# Altium schematic sheet 03-Power.SchDoc
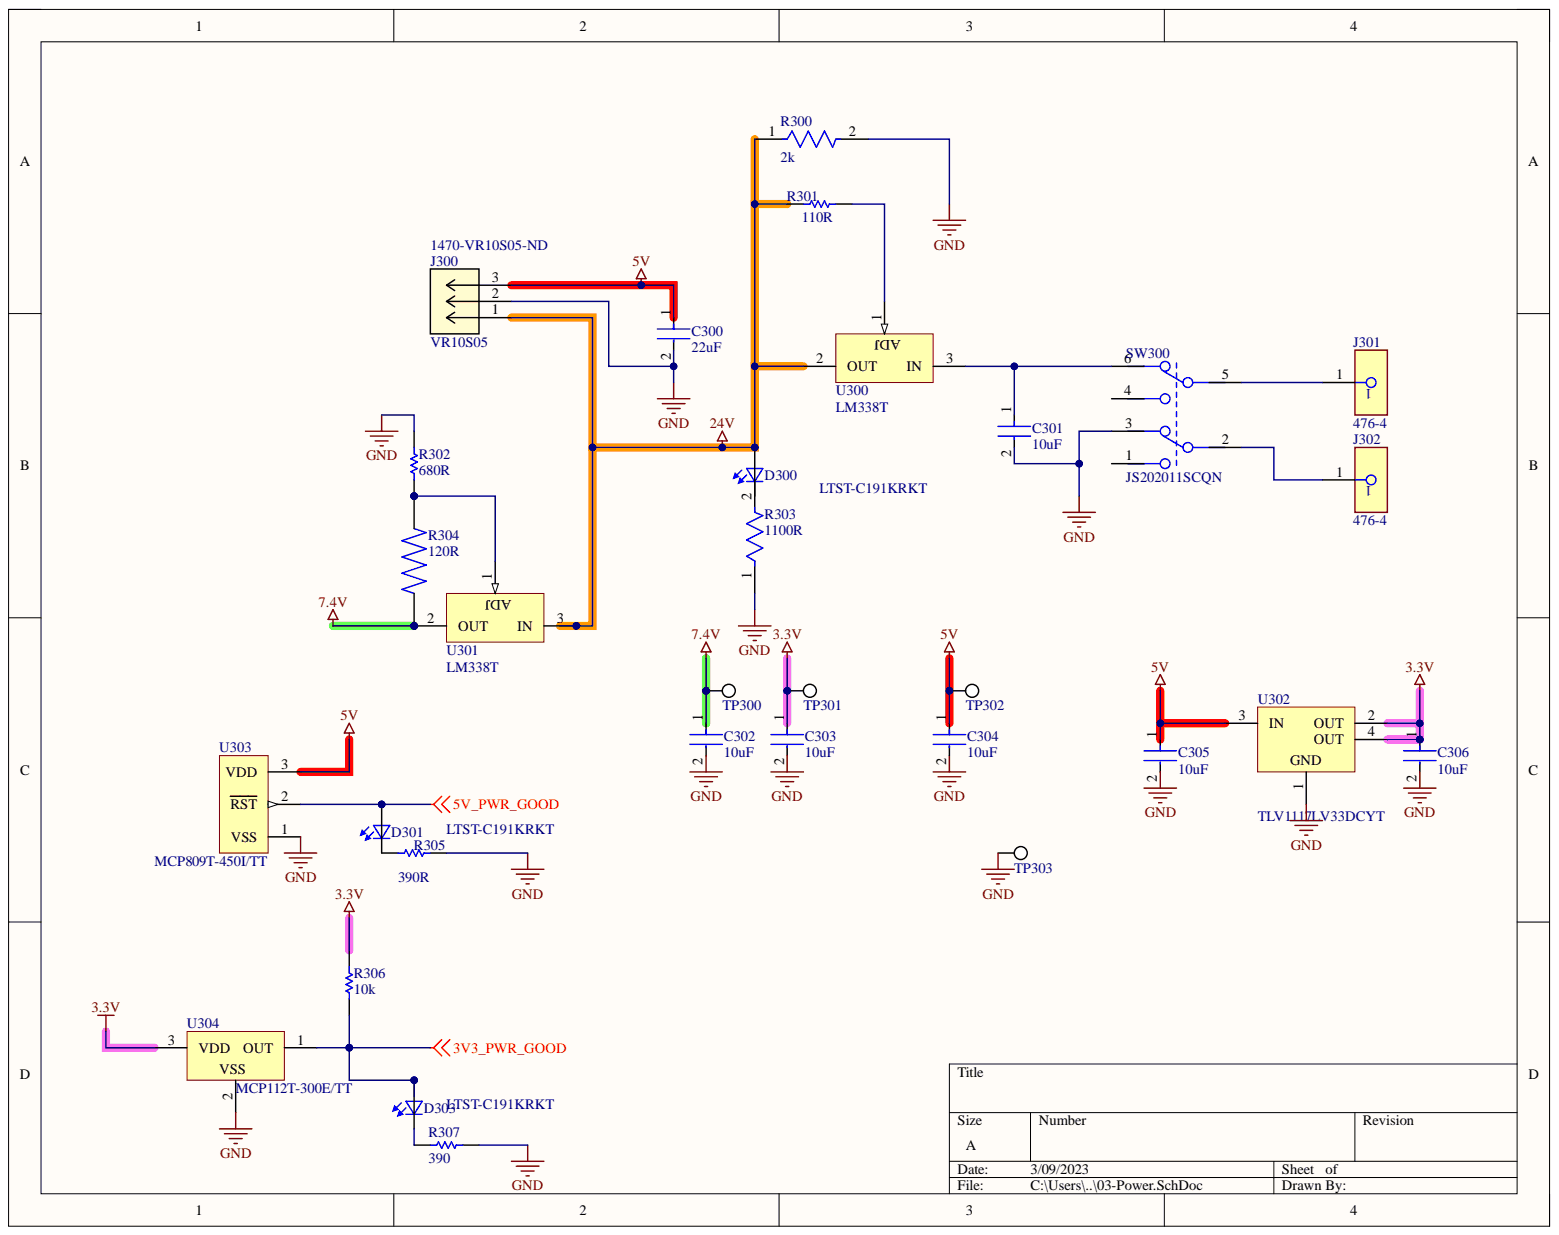
[diagram p0: 03-Power.SchDoc]

## Parts
C300  22uF  [FP-GRM21B-0_15-MFG]
C301  10uF  [FP-C0805C-DG-MFG]
C302  10uF  [FP-C0805C-DG-MFG]
C303  10uF  [FP-C0805C-DG-MFG]
C304  10uF  [FP-C0805C-DG-MFG]
C305  10uF  [FP-C0805C-DG-MFG]
C306  10uF  [FP-C0805C-DG-MFG]
D300  LTST-C191KRKT  [0603]
D301  LTST-C191KRKT  [0603]
D303  LTST-C191KRKT  [0603]
J300  VR10S05  Supplier Part Number 1: 1470-VR10S05-ND  [CONN3_10S05_XPP]
J301  476-4  [FP-476-4-MFG]
J302  476-4  [FP-476-4-MFG]
R300  2k  [FP-CRCW0805-e3-IPC_B]
R301  110R  [RESC2013X60X40NL20T25]
R302  680R  [RESC2013X50X30NL20T20]
R303  1100R  [FP-ESR10-MFG]
R304  120R  [RESC2012X65N]
R305  390R  [RESC3216X70X50LL05T20]
R306  10k  [RESC2013X60X35ML10T20]
R307  390  [RESC3216X70X50LL05T20]
SW300  JS202011SCQN  [FP-JS202011SCQN-MFG]
TP300  5019  [KSTN-5019_V]  (value not drawn)
TP301  5019  [KSTN-5019_V]  (value not drawn)
TP302  5019  [KSTN-5019_V]  (value not drawn)
TP303  5019  [KSTN-5019_V]  (value not drawn)
U300  LM338T  [NDE0003A]
U301  LM338T  [NDE0003A]
U302  TLV1117LV33DCYT  [DCY0004A_M]
U303  MCP809T-450I/TT  [SOT-23-TT3_N]
U304  MCP112T-300E/TT  [SOT-23-TT3_L]

## Nets
3.3V: C303.1 C306.1 R306.2 TP301.1 U302.2(OUT) U302.4(OUT) U304.3
3V3_PWR_GOOD: D303.2 R306.1 U304.1
5V: C300.1 C304.1 C305.1 J300.3 TP302.1 U302.3(IN) U303.3
5V_PWR_GOOD: D301.2 U303.2
7.4V: C302.1 R304.1 TP300.1 U301.2
24V: D300.2 J300.1 R300.1 R301.1 U300.2 U301.3
GND: C300.2 C301.2 C302.2 C303.2 C304.2 C305.2 C306.2 J300.2 R300.2 R302.2 R303.1 R305.2 R307.1 SW300.3 TP303.1 U302.1 U303.1 U304.2
NetC301_1: C301.1 SW300.6 U300.3
NetD301_1: D301.1 R305.1
NetD303_1: D303.1 R307.2
NetJ301_1: J301.1 SW300.5
NetJ302_1: J302.1 SW300.2
NetR301_2: R301.2 U300.1
NetR302_1: R302.1 R304.2 U301.1

Not connected: D300 1; R303 2; SW300 1 4

## Library data of the parts
C300 = CMP-2007-03385-2: Manufacturer: Murata; Manufacturer Part Number: GRM21BR60J226ME39L; Dielectric: X5R; Material: Ceramic; Tolerance: 20%; Voltage: 6.3V; Capacitance: 22uF; Case Code (Imperial): 0805; Case Code (Metric): 2012; Case/Package: 0805; Category: Ceramic Capacitors; Component Kind: Standard; Construction: Flat; Depth: 1.25mm; Description: 22uF; Height: 1.25mm; Lead Free: Lead Free; Length: 2mm; Library Name: Altium Content Vault; Max Operating Temperature: 85°C; Min Operating Temperature: -55°C; Mount: Surface Mount; Package Quantity: 1; Packaging: Tape and Reel; Pricing 1: 1=0.37000, 10=0.25600, 50=0.17940, 100=0.15380, 500=0.11532, 1000=0.09739 (USD); Radiation Hardening: No; REACH SVHC: No SVHC; Reach SVHC Compliant: Yes; RoHS: Yes; RoHS Compliant: Yes; Series: GRM; Stock 1: 2705091; Supplier 1: Digi-Key; Supplier Part Number 1: 490-1719-1-ND; Termination: SMD/SMT; Thickness: 0.053inch; Voltage Rating: 6.3V; Voltage Rating (DC): 6.3V; Width: 0.049inch
C301-C306 = CMP-2007-03243-2: 3DS Part Number: 220-00001; Manufacturer: Kemet; Manufacturer Part Number: C0805C106K8PACTU; Material: Ceramic; Temperature Characteristic: X5R; Temperature Coefficient: 15%; Tolerance: 10%; Voltage: 10V; Automotive: No; Capacitance: 10uF; Category: Cap; Component Kind: Standard; ComponentLink2Description: Datasheet; ComponentLink2URL: https://content.kemet.com/datasheets/KEM_C1006_X5R_SMD.pdf; Description: 10uF; Device Class L1: Passive Components; Device Class L2: Capacitors; Device Class L3: Ceramic Capacitors; Digikey Description: CAP CER 10UF 10V X5R 0805; Height: 1.4mm; Ipc Land Pattern Name: CAPC200125X125; Lead Free: Yes; Library Name: Altium Content Vault; Mouser Description: CAP CER 10UF 10V X5R 0805; Octopart: https://octopart.com/c0805c106k8pactu-kemet-98697; Package: C0805C-DG; RoHS: TRUE; Temperature: -55°C to +85°C; Temperature Range High: +85°C; Temperature Range Low: -55°C; Value: 10uF; Voltage Rating: 10V
D300 D301 D303 = LTST-C191KRKT: http://octopart.com/ltst-c190krkt-lite-on-549893; Availability: Unavailable; Component Kind: Standard; Library Name: LTST-C191KRKT.SchLib; MP: LTST-C191KRKT; Package: None; Price: None; Supplier 1: Digi-Key; Supplier Part Number 1: 160-1447-1-ND
J300 = VR10S05: DC-DC Converter, Switching Regulater, 1A, SIP, VR Series; Manufacturer: XP Power; Category: Board Mount Modules; Component Kind: Standard; Library Name: VR10S05.SchLib; Manufacturer Part Number: VR10S05; Mfr_Name: XP Power; Pricing 1: 1=2.85000, 5=2.76400, 10=2.67900, 25=2.56480, 100=2.39400 (USD); RefDes: RefDes; RoHS: No; Stock 1: 1761; Supplier 1: Digi-Key; Type: Type
J301 J302 = CMP-19636-000003-1: PLUG JACK MINI NON-INSULATED; Manufacturer: Keystone Electronics; Conn Orientation: Straight; Current Rating: 10A; Is Female: yes; Number Of Contacts: 1; Automotive: No; Category: Conn; Component Kind: Standard; ComponentLink2Description: Datasheet; ComponentLink2URL: https://www.keyelco.com/userAssets/file/M65p111.pdf; Contact Material: Tin; Device Class L1: Connectors; Device Class L2: unset; Device Class L3: unset; Digikey Description: PLUG JACK MINI NON-INSULATED; Footprint Url: https://www.keyelco.com/product-pdf.cfm?p=2378; Lead Free: Yes; Library Name: Altium Content Vault; Manufacturer Part Number: 476-4; Mouser Description: Test Plugs & Test Jacks BANANA JACK NON-INSUL; Octopart: https://octopart.com/476-4-keystone-225161; Package: 476-4; RoHS: TRUE
R300 = CMP-2001-03755-2: RES Thick Film, 2kΩ, 1%, 0.125W, 100ppm/°C, 0805; Max Power Dissipation: 125mW; Resistance: 2kR; Voltage Rating: 150V; Case Code (Imperial): 0805; Case Code (Metric): 2012; Case/Package: 0805; Component Kind: Standard; Composition: Thick Film; Contact Plating: Tin; Depth: 1.25mm; Features: Automotive AEC-Q200; Height: 0.02inch; Lead Free: Lead Free; Length: 2mm; Library Name: Altium Content Vault; Max Operating Temperature: 155°C; Military Standard: Not; Min Operating Temperature: -55°C; Mount: Surface Mount; Number of Pins: 2; Number of Terminals: 2; Number of Terminations: 2; Package Quantity: 10; Packaging: Tape and Reel; Power Rating: 125mW; Radiation Hardening: No; Temperature Coefficient: 100ppm/°C; Termination: SMD/SMT; Tolerance: 1%; Voltage Rating (DC): 150V; Weight: 0.000194oz; Width: 1.25mm; Working Voltage: 150V
R301 = CMP-2001-00585-1: Manufacturer: Panasonic; Part Number: ERA6AEB111V; Component Kind: Standard; Library Name: Altium Content Vault
R302 = CMP-2001-04066-1: Manufacturer: Vishay Dale; Part Number: CRCW0805680RFKEA; Component Kind: Standard; Library Name: Altium Content Vault
R303 = CMP-08839-007508-1: 1.1kΩ ±1% 0.4W 0805 Anti-Surge Chip Resistor AEC-Q200; Max Power Dissipation: 400mW; Resistance: 1.1kR; Temperature Coefficient: 100ppm/°C; Case Code (Imperial): 0805; Case Code (Metric): 2012; Case/Package: 0805; Component Kind: Standard; Features: Automotive AEC-Q200; Height: 0.026inch; Lead Free: Lead Free; Length: 0.079inch; Library Name: Altium Content Vault; Max Operating Temperature: 155°C; Min Operating Temperature: -55°C; Number of Terminations: 2; Package Quantity: 1; Packaging: Tape and Reel; Power Rating: 400mW; Resistor Type: Thick Film; RoHS Compliant: Yes; Series: ESR; Size Code: 0805; Tolerance: 1%; Width: 0.049inch
R304 = CRGCQ0805F120R: Fixed Resistor CRGCQ 0805 120R 1%; MF: TE Connectivity; Availability: Unavailable; Component Kind: Standard; Library Name: CRGCQ0805F120R.IntLib; MP: CRGCQ0805F120R; Package: 2012 TE Connectivity; Price: None; SnapEDA_Link: https://www.snapeda.com/parts/CRGCQ0805F120R/TE%20Connectivity/view-part/3187861/?ref=snap; Supplier 1: Digi-Key; Supplier Part Number 1: A129738CT-ND; TE_purchase_URL: https://www.te.com/usa-en/product-1-2176341-4.html?te_bu=Cor&te_type=disp&te_campaign=seda_glo_cor-seda-global-disp-prtnr-fy19-seda-model-bom-cta_sma-317_1&elqCampaignId=32493
R305 R307 = CMP-1014-00463-2: Manufacturer: Panasonic; Part Number: ERJ8ENF3900V; Component Kind: Standard; Library Name: Altium Content Vault
R306 = CMP-2000-05025-1: Chip Resistor, 10 KOhm, +/- 5%, 0.125 W, -55 to 155 degC, 0805 (2012 Metric), RoHS, Tape and Reel; Manufacturer: Yageo; Power Rating: 250mW; Product Range: RCSeries; Resistance: 10kohm; Resistor Case Style: 0805[2012Metric]; Resistor Element Type: ThickFilm; SVHC: ToBeAdvised; Temperature Coefficient: ±100ppm/degC; Voltage Rating: 150V; Automotive Qualification Standard: -; Component Kind: Standard; Datasheet URL: http://www.yageo.ru/pdf/RC0805.pdf; Datasheet Version: REV. 2, 09/2004; Library Name: Altium Content Vault; Manufacturer URL: http://www.yageo.com/; MSL: MSL1-Unlimited; Package Description: 2-Pin Surface Mounted Device, Body 2 x 1.25 mm; Package Reference: 805; Packaging: CutTape; Resistance Tolerance: ±5%
SW300 = CMP-2000-05087-3: SWITCH SLIDE DPDT 300MA 6V; Actuator Color: Black; Actuator Length: 2mm; Actuator Material: Nylon; Actuator Type: Standard; Contact Resistance: 70mR; Electrical Life: 5000Cycles; Switch Function: On-On; Component Kind: Standard; Contact Current Rating: 300mA; Contact Material: Copper Alloy; Contact Plating: Silver; Current Rating: 300mA; Depth: 7.5mm; Flammability Rating: UL94 V-2; Housing Material: Nylon; Lead Free: Lead Free; Length: 9mm; Library Name: Altium Content Vault; Max Current Rating: 300mA; Max Operating Temperature: 85°C; Max Voltage Rating (DC): 6V; Min Operating Temperature: -20°C; Mount: Surface Mount; Orientation: Vertical; Package Quantity: 1; Packaging: Tape and Reel; Radiation Hardening: No; REACH SVHC: No SVHC; Series: JS; Switch Travel: 2mm; Termination: Gull Wing; Throw Configuration: DPDT; Voltage Rating (DC): 6V
TP300-TP303 = CMP-1672-00008-1: Test Point, 1 Position SMD, RoHS, Tape and Reel; Manufacturer 1: Keystone Electronics; Category: Connectors; Component Kind: Standard; ComponentLink1Description: Manufacturer URL; ComponentLink1URL: http://www.keyelco.com/; ComponentLink2Description: Datasheet; ComponentLink2URL: http://www.keyelco.com/product-pdf.cfm?p=1357; ComponentLink3Description: https://datasheet.ciiva.com/16318/m60-2p61-16318395.pdf?src-supplier=Future+Electronics; ComponentLink3URL: https://datasheet.ciiva.com/16318/m60-2p61-16318395.pdf?src-supplier=Future+Electronics; ComponentLink4Description: https://datasheet.ciiva.com/16885/70182998-16885945.pdf?src-supplier=Allied+Electronics; ComponentLink4URL: https://datasheet.ciiva.com/16885/70182998-16885945.pdf?src-supplier=Allied+Electronics; ComponentLink5Description: https://datasheet.ciiva.com/14848/m60-2p61-14848437.pdf?src-supplier=Digi-Key; ComponentLink5URL: https://datasheet.ciiva.com/14848/m60-2p61-14848437.pdf?src-supplier=Digi-Key; ComponentLink6Description: https://datasheet.ciiva.com/14848/m60-2p61-14848438.pdf?src-supplier=Digi-Key; ComponentLink6URL: https://datasheet.ciiva.com/14848/m60-2p61-14848438.pdf?src-supplier=Digi-Key; ComponentLink7Description: https://datasheet.ciiva.com/14848/m60-2p61-14848439.pdf?src-supplier=Digi-Key; ComponentLink7URL: https://datasheet.ciiva.com/14848/m60-2p61-14848439.pdf?src-supplier=Digi-Key; Contact Material: Bronze; Contact Plating: Silver; DatasheetVersion: 03/2014; Height: 0.09inch; Lead Free: Lead Free; Library Name: Altium Content Vault; Manufacturer Part Number 1: 5019; Material: Bronze; Mount: Surface Mount; Mounting Technology: Surface Mount; Number of Pins: 1; Package Quantity: 1000; PackageDescription: 1-Pin Surface Mount Device, Body 3.81 x 2.03 mm; PackageReference: 5019; Packaging: Tape and Reel; Pins: 1; Pricing 1: 1=0.34000, 25=0.27360, 100=0.22340, 250=0.20064, 500=0.19152 (USD); REACH SVHC: No SVHC; RoHS: Yes; RoHS Compliant: Yes; RoHSCompliant: True; Series: 5019; Stock 1: 1316816; Supplier 1: Digi-Key; Supplier Part Number 1: 36-5019CT-ND; Termination: SMD/SMT
U300 U301 = CMP-0062-02475-3: 5 Amp Adjustable Regulator, 3-pin TO-220; Manufacturer: Texas Instruments; CompType: LDO IC; PackageTopMarking: NSUZXYTTE#*LM338T P+; PowerWise: N; Component Kind: Standard; ComponentLink1Description: Datasheet URL; ComponentLink1URL: http://www.ti.com/general/docs/lit/getliterature.tsp?genericPartNumber=LM338&fileType=pdf; Library Name: Altium Content Vault; PackageDescription: TO-220, Molded, 3 Lead, Straight; PackageReference: NDE0003A; PinCount: 3; RoHS: N
U302 = CMP-0395-00499-2: Single Output LDO, 1 A, Fixed 3.3 V Output, 2 to 5.5 V Input, 4-pin SOT-223 (DCY), -40 to 125 degC, Green (RoHS & no Sb/Br); Manufacturer: Texas Instruments; Accuracy(%): 2; Fixed Output Options(V): 3.3; Iout(Max)(A): 1; Iq(Typ)(mA): 0.05; SubFamily: Single Channel LDO; Vdo(Typ)(mV): 1200; Vin(Max)(V): 5.5; Code_JEDEC: TO-261; Component Kind: Standard; ComponentLink1Description: Manufacturer URL; ComponentLink1URL: http://www.ti.com/; ComponentLink2Description: Datasheet; ComponentLink2URL: http://www.ti.com/general/docs/lit/getliterature.tsp?genericPartNumber=TLV1117LV33&fileType=pdf; ComponentLink3Description: Package Specification; ComponentLink3URL: http://www.ti.com/litv/pdf/mpds094a; DatasheetVersion: SBVS160A; Eco-Plan: Green (RoHS & no Sb/Br); Library Name: Altium Content Vault; Mounting Technology: Surface Mount; PackageDescription: 4-Pin Plastic Small Outline, Body 3.5 x 6.5 mm, Pitch 2.3 mm; PackageReference: DCY0004A; PackageVersion: 4202506/B; PartNumber: TLV1117LV33DCYT; Vin(Min)(V): 2; Vout Adj(Max)(V): 3.3; Vout Adj(Min)(V): 3.3
U303 = CMP-0138-00383-1: Microcontroller Supervisory Circuit with Push-Pull Output, 3-Pin SOT-23, Industrial Temperature, Tape and Reel; Code_IPC: SOT23-3; Component Kind: Standard; DatasheetVersion: revC, 2001; Library Name: Altium Content Vault; PackageDescription: 3-Lead Plastic Small Outline Transistor (TT) [SOT-23]; PackageReference: SOT-23-TT3; PackageVersion: revBB, Aug-2009
U304 = CMP-0130-00043-1: 1.75Î¼A Micropower Voltage Detector, Push-Pull Output, 2.93V, 3-Pin SOT-23, Extended Temperature, Tape and Reel; Code_IPC: SOT23-3; Component Kind: Standard; DatasheetVersion: revD, Jun-2005; Library Name: Altium Content Vault; PackageDescription: 3-Lead Plastic Small Outline Transistor (TT) [SOT-23]; PackageReference: SOT-23-TT3; PackageVersion: revBB, Aug-2009
